# Altium schematic sheet DistrValveBrd.SchDoc(DistrValveBrd)
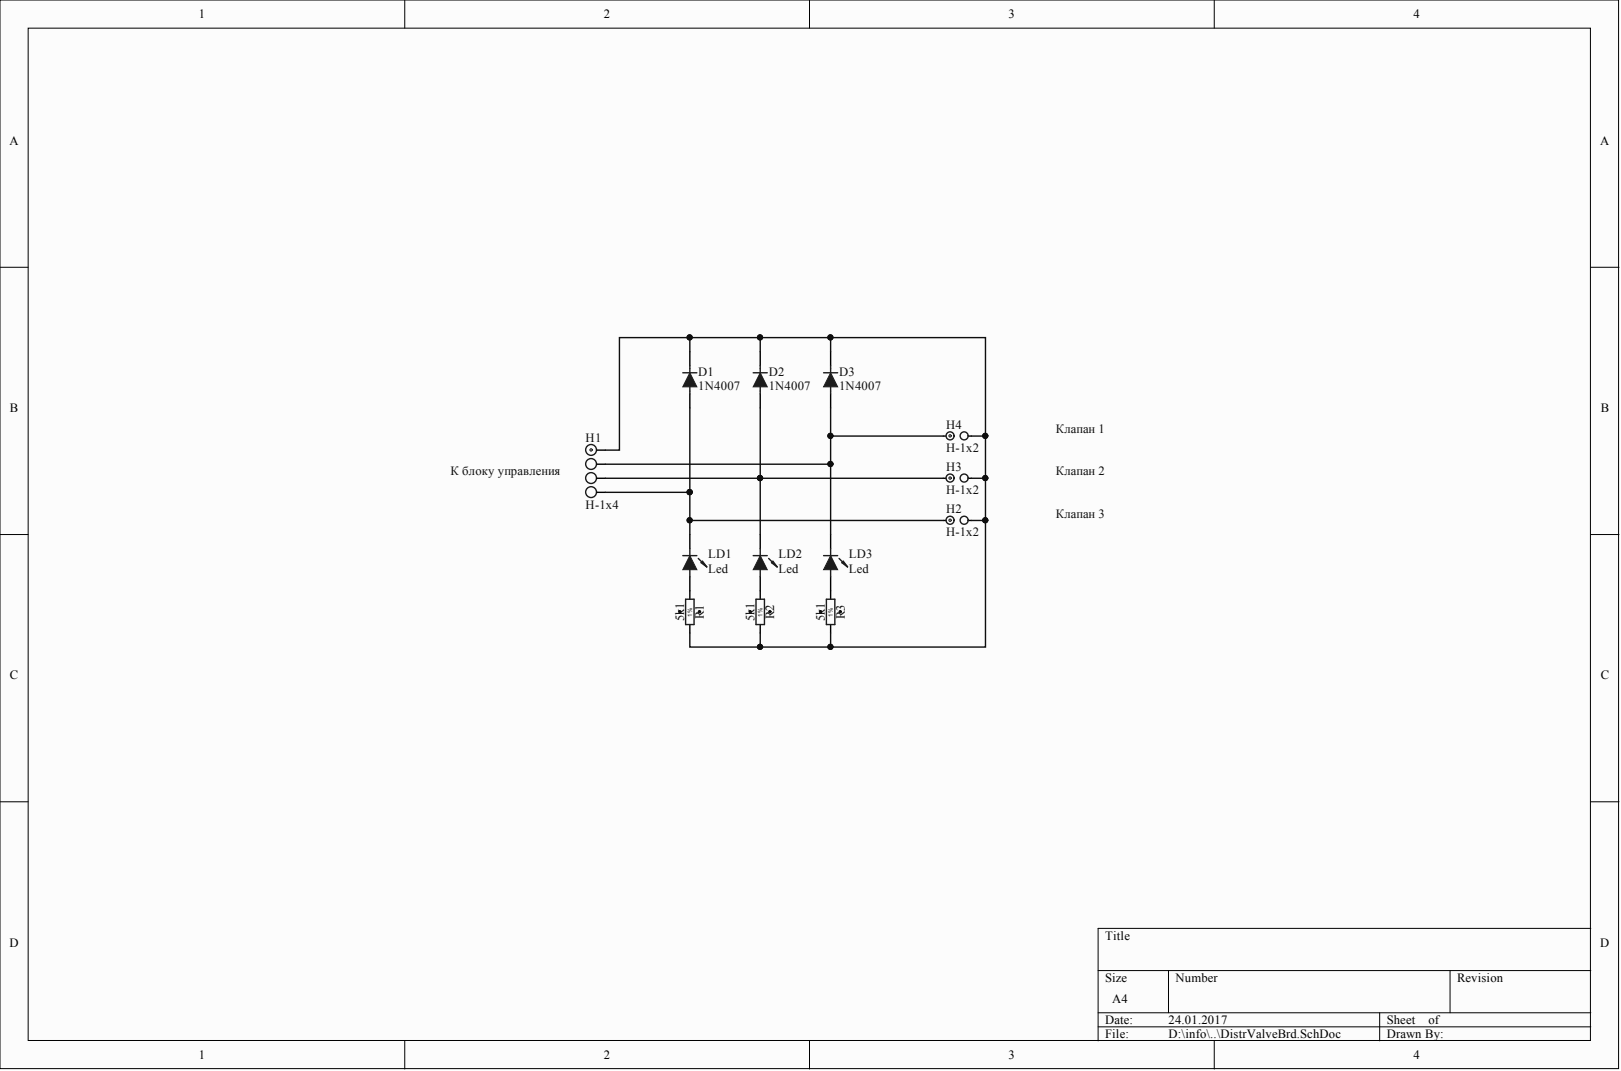
[diagram p0: DistrValveBrd.SchDoc]

## Parts
D1  1N4007  [DO-41-300]
D2  1N4007  [DO-41-300]
D3  1N4007  [DO-41-300]
H1  H-1x4  [J1x4]
H2  H-1x2  [J1x2]
H3  H-1x2  [J1x2]
H4  H-1x2  [J1x2]
LD1  Led  [Led d5mm]
LD2  Led  [Led d5mm]
LD3  Led  [Led d5mm]
R1  5k1  [RESC2012X60N]
R2  5k1  [RESC2012X60N]
R3  5k1  [RESC2012X60N]

## Nets
NetD1_1: D1.1 H1.4 H2.1 LD1.2
NetD1_2: D1.2 D2.2 D3.2 H1.1 H2.2 H3.2 H4.2 R1.2 R2.2 R3.2
NetD2_1: D2.1 H1.3 H3.1 LD2.2
NetD3_1: D3.1 H1.2 H4.1 LD3.2
NetLD1_1: LD1.1 R1.1
NetLD2_1: LD2.1 R2.1
NetLD3_1: LD3.1 R3.1

## Library data of the parts
D1-D3 = 1N4007: 1000V 1A; Component Kind: Standard; Library Name: Diodes-Power.IntLib; Price: 0.05; Signal Integrity: D; Simulation: D1N4007
LD1-LD3 = Led-5mm: 5mm; Component Kind: Standard; Library Name: Ind LED-LCD.IntLib; Price: 0.05
R1-R3 = R0805_5K1_1%_0.125W_100PPM: Resistor - 1%; Manufacturer: GENERIC; Manufacturer1 Part Number: NRC10F5101TRF; Part Description: General Purpose Thick Film Chip Resistor; Database Table Name: Resistors SMD; Power: 0.125W; TC: ±100ppm/°C; Case: 0805; Component Kind: Standard; Component Type: Standard; CreateDate: 03.12.2007; HelpURL: \\cern.ch\dfs\Applications\Altium\Datasheets\R0805_NIC_NRC.pdf; LatestRevisionDate: 13.03.2008; Library Name: Resistors.DbLib; Manufacturer1 ComponentHeight: 0.6mm; Manufacturer1 Example: NIC COMPONENT; Manufacturer Part Number: R0805_5K1_1%_0.125W_100PPM; Mounted: Yes; Part Number: R0805_5K1_1%_0.125W_100PPM; Pin Count: 2; SMD: Yes; Socket: No; Status: Preferred; Table: Resistors SMD; Tolerance: ±1%; Value: 5k1; Author: CERN DEM JLC
H1 = H-1x4: Component Kind: Standard; Library Name: Connektor.IntLib; Price: 0.04
H2-H4 = H-1x2: Component Kind: Standard; Library Name: Connektor.IntLib; Price: 0.02
